# Altium schematic sheet Sch-QWA17_USB-SW-Boot.SchDoc(Sch-QWA17_USB-SW-Boot)
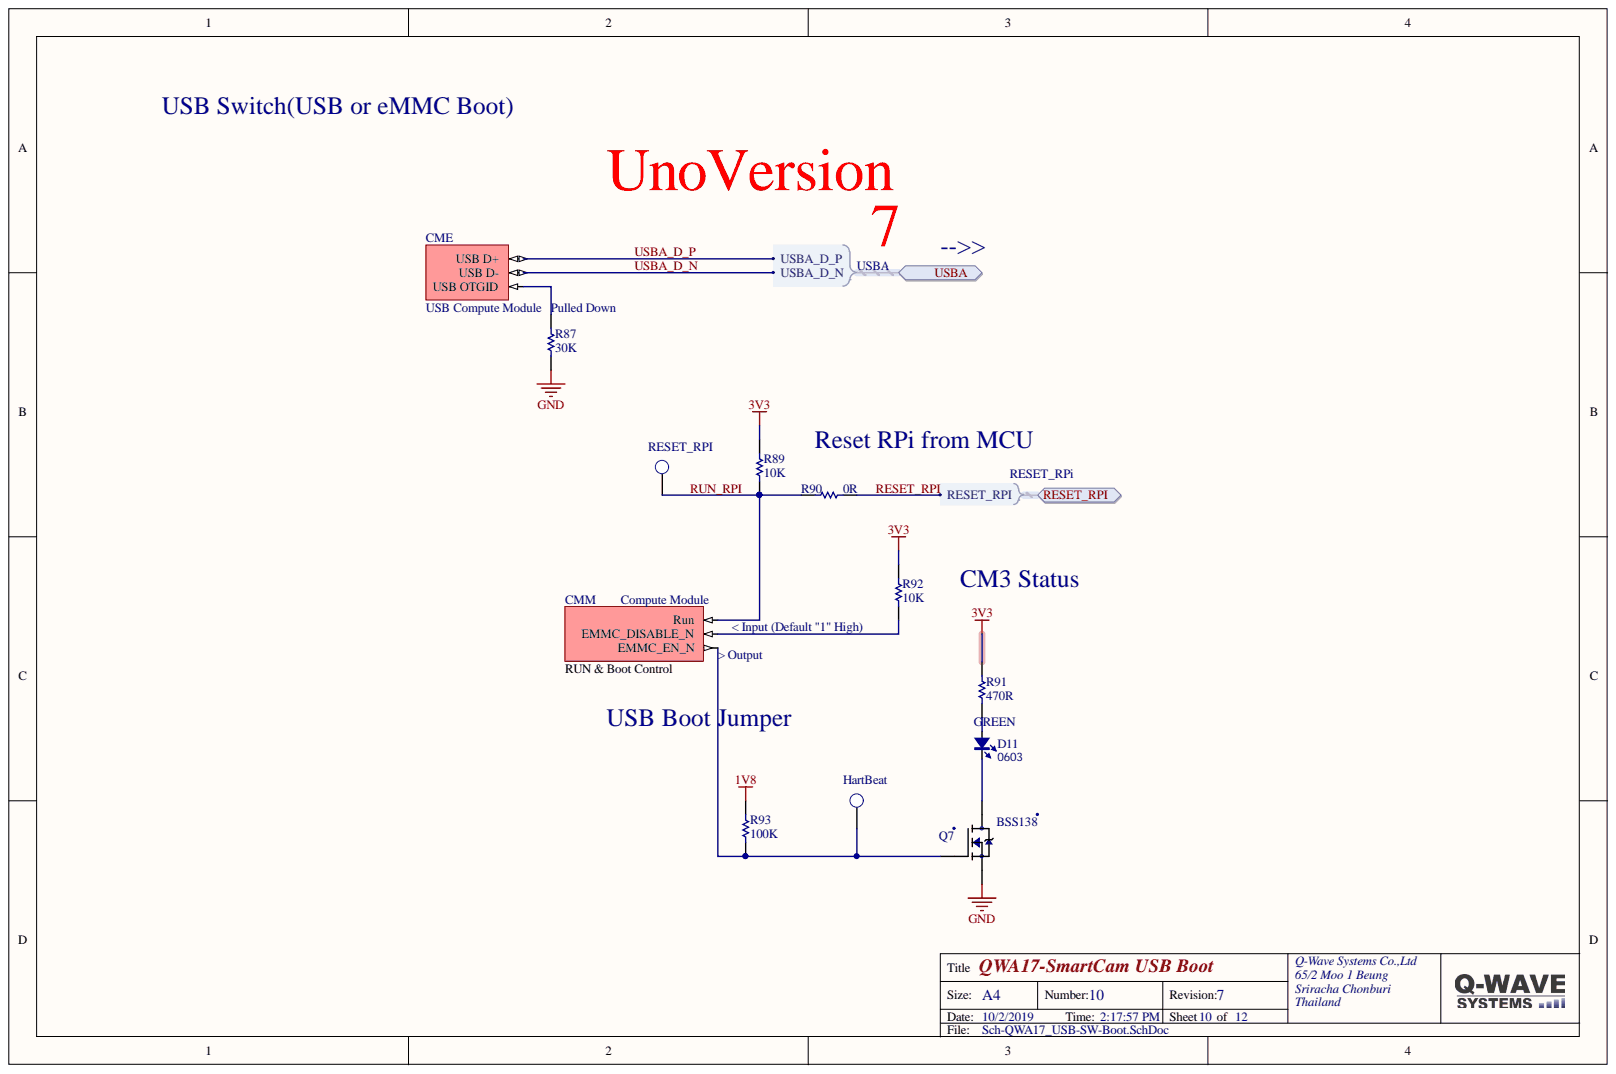
[diagram p0: Sch-QWA17_USB-SW-Boot.SchDoc]

## Parts
CM  Compute Module  [1473005-4]  (parts E,M)
D11  GREEN  Type: 0603  [LED_0603_GREEN]
HartBeat  HartBeat  [TEST_POINT]
Q7  BSS138  [SOT-23-3]
R87  30K  [RES_0603]
R89  10K  [RES_0603]
R90  0R  [RES_0603]
R91  470R  [RES_0603]
R92  10K  [RES_0603]
R93  100K  [RES_0603]
RESET_RPI  RESET_RPI  [TEST_POINT]

## Ports
RESET_RPI, RESET_RPI.RESET_RPI, USBA, USBA.USBA_D_N, USBA.USBA_D_P

## Nets
1V8: R93.1
3V3: R89.1 R91.2 R92.2
GND: Q7.2 R87.2
RESET_RPI: R90.2 ; port RESET_RPI
RUN_RPI: CM.177(Run) R89.2 R90.1 RESET_RPI.1
USBA_D_N: CM.167(USB_D-) ; port USBA
USBA_D_P: CM.165(USB_D+) ; port USBA
NetCM_2: CM.2(EMMC_DISABLE_N) R92.1
NetCM_90: CM.90(EMMC_EN_N) HartBeat.1 Q7.1 R93.2
NetCM_168: CM.168(USB_OTGID) R87.1
NetD11_1: D11.1 R91.1
NetD11_2: D11.2 Q7.3

## Library data of the parts
CM = Compute Module: Raspberry Pi Compute Module; Component Kind: Standard; Library Name: Compute Module.SchLib
D11 = LED_0603: LED; Value: color?; Component Kind: Standard; Library Name: SCH_Active.SchLib
HartBeat RESET_RPI = TEST_POINT: Test Point; Component Kind: Standard; Library Name: Misc.SCHLIB
Q7 = BSS138: N-Channel 50V 220mA (Ta) 360mW (Ta) Surface Mount SOT-23-3; MFG: ON Semiconductor; Availability: Unavailable; Component Kind: Standard; MFG P/N: BSS138; Package: SOT-23 Diodes Inc.; Price: 0.21 USD; Supplier1: ES; Supplier_Part_Number: 0016-0377-1; Supplier_Purchase_URL: https://www.es.co.th/detail.asp?Prod=001603771; Library Name: EspVIEW.IntLib
R87 R89 R90 R92 R93 = Res Semi: Resistor 0603; Component Kind: Standard; Library Name: Miscellaneous Devices.IntLib; Simulation: RES
R91 = Res Semi: 470R Resistor 0603; Component Kind: Standard; Library Name: SCH_Passive.SchLib; Simulation: RES
